# Altium schematic sheet Umgebungssensor_PCB.SchDoc(Umgebungssensor_PCB)
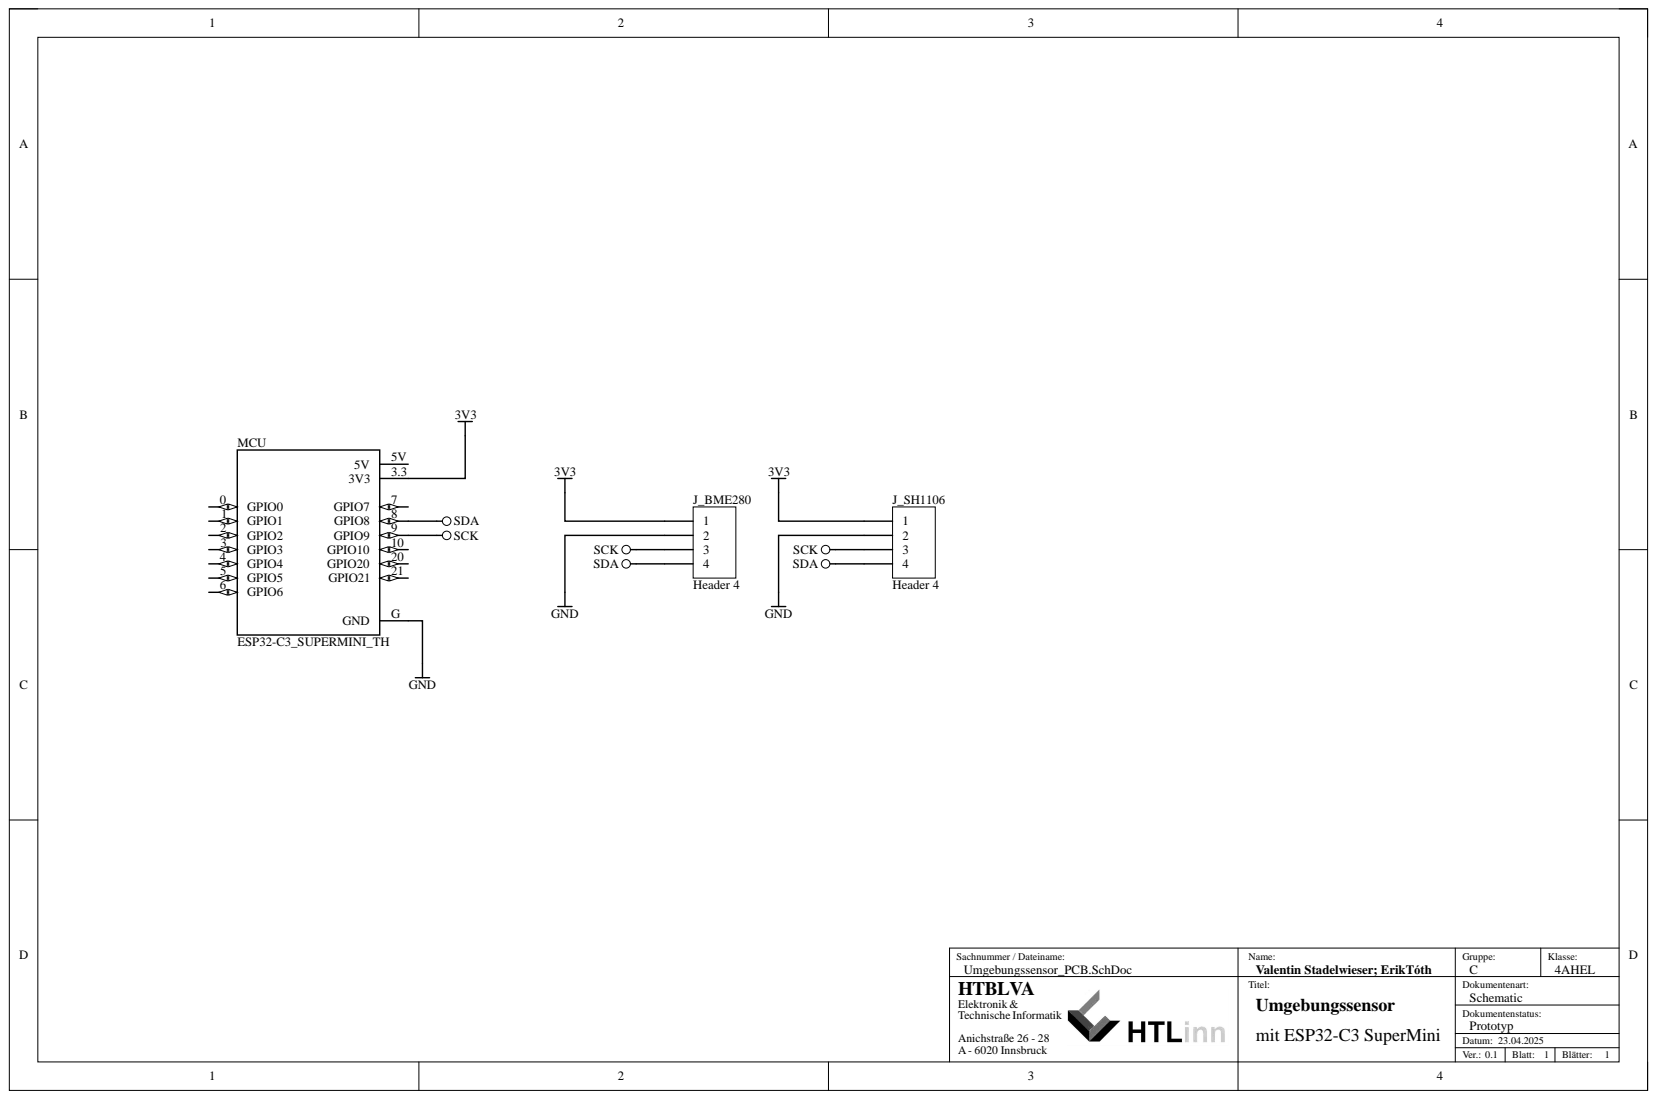
[diagram p0: Umgebungssensor_PCB.SchDoc]

## Parts
J_BME280  Header 4  [HDR1X4]
J_SH1106  Header 4  [HDR1X4]
MCU  ESP32-C3_SUPERMINI_TH  [MODULE_ESP32-C3_SUPERMINI]

## Nets
3V3: J_BME280.1 J_SH1106.1 MCU.3.3(3V3)
GND: J_BME280.2 J_SH1106.2 MCU.G(GND)
SCK: J_BME280.3 J_SH1106.3 MCU.9(GPIO9)
SDA: J_BME280.4 J_SH1106.4 MCU.8(GPIO8)

Not connected: MCU 0(GPIO0) 1(GPIO1) 2(GPIO2) 3(GPIO3) 4(GPIO4) 5(GPIO5) 5V 6(GPIO6) 7(GPIO7) 10(GPIO10) 20(GPIO20) 21(GPIO21)

## Library data of the parts
J_BME280 J_SH1106 = Header 4: Header, 4-Pin; Component Kind: Standard; LatestRevisionDate: 17-Jul-2002; LatestRevisionNote: Re-released for DXP Platform.; Library Name: Miscellaneous Connectors.IntLib; Signal Integrity: Connector; Publisher: Altium Limited
MCU = ESP32-C3_SUPERMINI_TH: Super tiny ESP32-C3 board; MF: Espressif Systems; MP: ESP32-C3 SuperMini_TH; Check_prices: https://www.snapeda.com/parts/ESP32-C3%20SuperMini_TH/Espressif+Systems/view-part/?ref=eda; Component Kind: Standard; Library Name: ESP32-C3_SUPERMINI_TH.IntLib; Package: Package; SnapEDA_Link: https://www.snapeda.com/parts/ESP32-C3%20SuperMini_TH/Espressif+Systems/view-part/?ref=snap
